# Altium schematic sheet Sheet2.SchDoc(Sheet2)
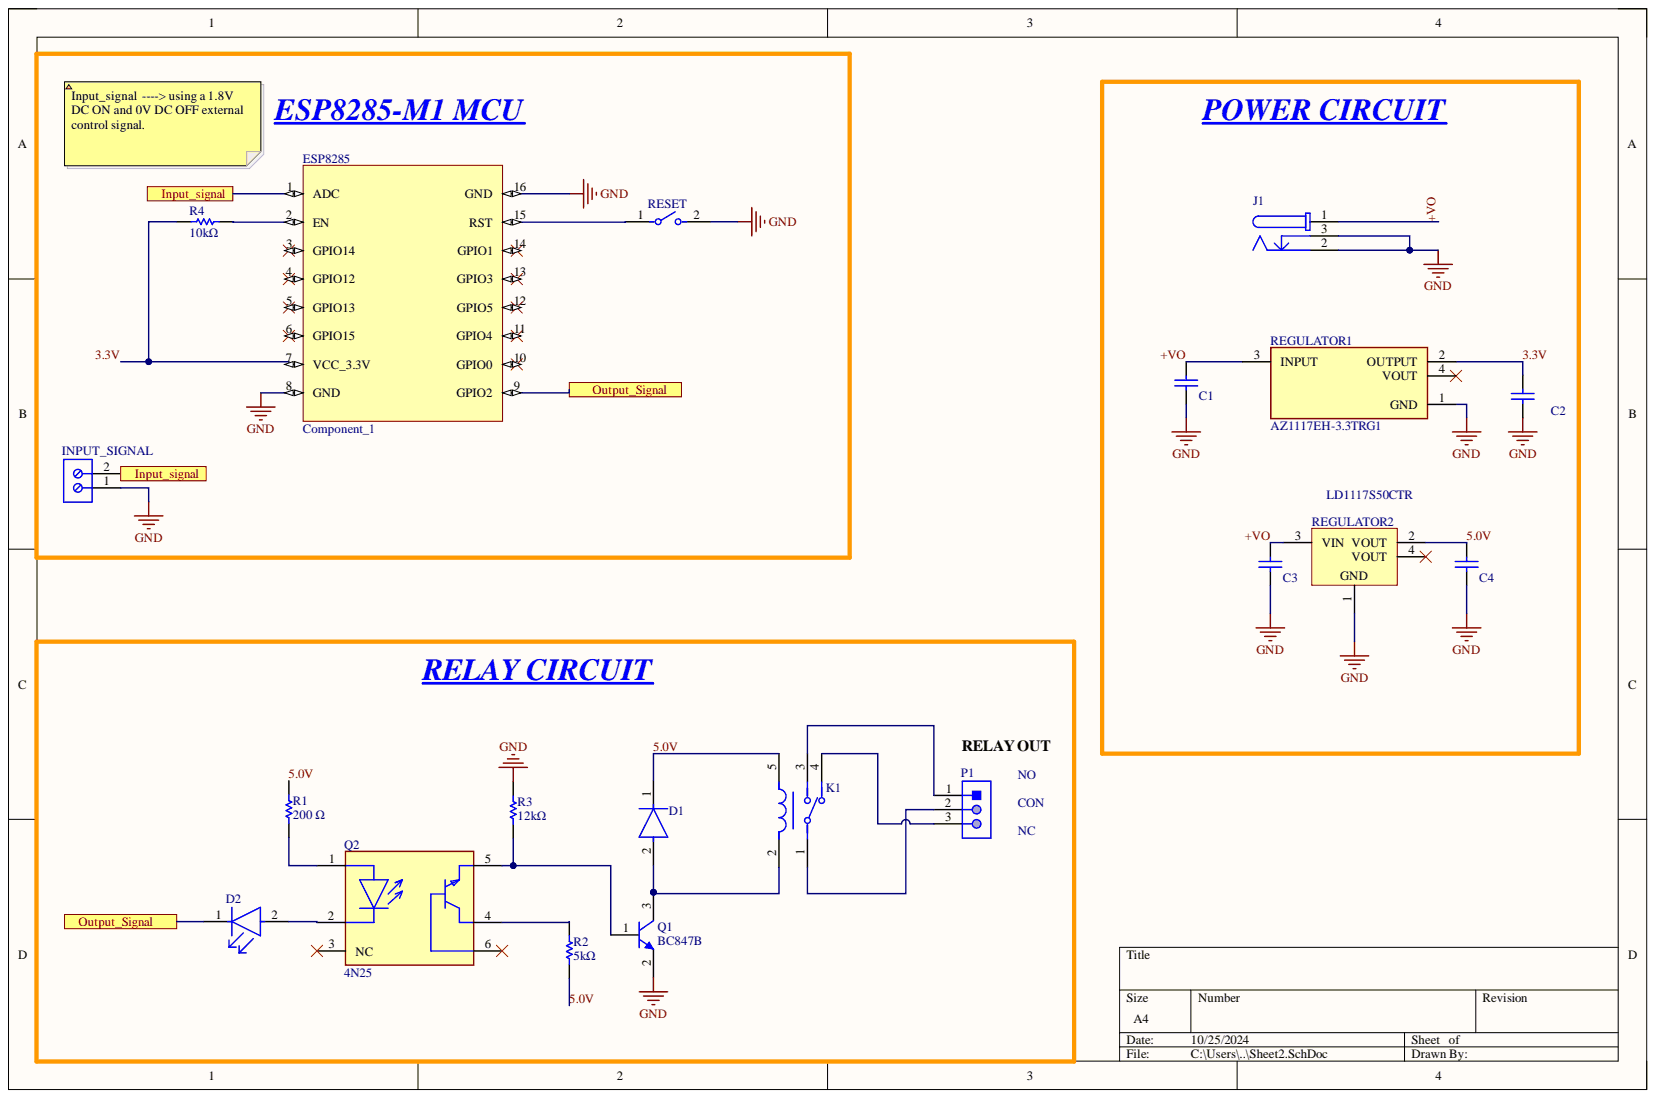
[diagram p0: Sheet2.SchDoc]

## Parts
C1  0603YC104KAT4A  [CAPC1608X90X35LL15T15]  (value not drawn)
C2  0603YC104KAT4A  [CAPC1608X90X35LL15T15]  (value not drawn)
C3  0603YC104KAT4A  [CAPC1608X90X35LL15T15]  (value not drawn)
C4  0603YC104KAT4A  [CAPC1608X90X35LL15T15]  (value not drawn)
D1  PMLL4148L,115  [FP-SOD80C-MFG]  (value not drawn)
D2  LTST-C190GKT  [FP-LTST-C190GKT-MFG]  (value not drawn)
ESP8285  Component_1  [ESP8285-M1]
INPUT_SIGNAL  691101710002  [691101710002]  (value not drawn)
J1  694106301002  [694106301002]  (value not drawn)
K1  G5LE-14-DC5  [FP-G5LE-14-DC5-MFG]  (value not drawn)
P1  691311500103  [691311500103]  (value not drawn)
Q1  BC847B  [INF-SOT23_M]
Q2  4N25  [FP-4N25-MFG]
R1  200 Ω  [RESC3225X70X50ML20T20]
R2  5kΩ  [RESC3225X70X50ML20T20]
R3  12kΩ  [RESC3225X70X50ML20T20]
R4  10kΩ  [RESC3225X70X50ML20T20]
REGULATOR1  AZ1117EH-3.3TRG1  [FP-SOT223-MFG]
REGULATOR2  LD1117S50CTR  [SOT-223_M]
RESET  PTS635SL43SMTR LFS  [FP-PTS635SL43SMTR_LFS-MFG]  (value not drawn)

## Ports
Input_signal, Output_Signal

## Nets
GND: C1.1 C2.1 C3.1 C4.1 ESP8285.8(GND) ESP8285.16(GND) INPUT_SIGNAL.1 J1.2 J1.3 Q1.2 R3.2 REGULATOR1.1(GND) REGULATOR2.1 RESET.2
3.3V: C2.2 ESP8285.7(VCC_3.3V) R4.2 REGULATOR1.2(OUTPUT)
5.0V: C4.2 D1.1 K1.5 R1.1 R2.1 REGULATOR2.2(VOUT)
+VO: C1.2 C3.2 J1.1 REGULATOR1.3(INPUT) REGULATOR2.3(VIN)
Input_signal: ESP8285.1(ADC) INPUT_SIGNAL.2 ; port Input_signal
Output_Signal: D2.1 ESP8285.9(GPIO2) ; port Output_Signal
NetD1_2: D1.2 K1.2 Q1.3
NetD2_2: D2.2 Q2.2
NetESP8285_15: ESP8285.15(RST) RESET.1
NetK1_1: K1.1 P1.2
NetK1_3: K1.3 P1.1
NetK1_4: K1.4 P1.3
NetQ1_1: Q1.1 Q2.5 R3.1
NetQ2_1: Q2.1 R1.2
NetQ2_4: Q2.4 R2.2

Not connected: ESP8285 2(EN) 3(GPIO14) 4(GPIO12) 5(GPIO13) 6(GPIO15) 10(GPIO0) 11(GPIO4) 12(GPIO5) 13(GPIO3) 14(GPIO1); Q2 3(NC) 6; R4 1; REGULATOR1 4(VOUT); REGULATOR2 4(VOUT)

## Library data of the parts
C1-C4 = CMP-2006-04495-1: Manufacturer: AVX Interconnect / Elco; Component Kind: Standard; Library Name: Altium Content Vault; Part Number: 0603YC104KAT4A
D1 = CMP-14399-000003-1: DIODE GEN PURP 75V 200MA LLDS; Manufacturer: Nexperia; Capacitance: 4pF; Max Junction Temp: +200°C; Max Repetitive Reverse Voltage: 100V; Max Surge Forward Current: 0.5A; Peak Reverse Current: 0.025uA; Value: 4pF; Ambient Temperature Range High: +200°C; Ambient Temperature Range Low: -65°C; Automotive: No; Category: Diode; Component Kind: Standard; ComponentLink2Description: Datasheet; ComponentLink2URL: https://assets.nexperia.com/documents/data-sheet/PMLL4148L_PMLL4448.pdf; Device Class L1: Discrete Semiconductors; Device Class L2: Diodes; Device Class L3: Rectifier Diodes; Digikey Description: DIODE GEN PURP 75V 200MA LLDS; Footprint Url: https://assets.nexperia.com/documents/outline-drawing/SOD80C.pdf; Forward Current: 200mA; Height: 1.6mm; Lead Free: Yes; Library Name: Altium Content Vault; Manufacturer Part Number: PMLL4148L,115; Octopart: https://octopart.com/pmll4148l%2C115-nexperia-78741557; Package: SOD80C; Reverse Voltage: 75V; RoHS: TRUE; Temperature: to +200°C; Temperature Range High: +200°C
D2 = CMP-22017-000005-2: LED GREEN CLEAR CHIP SMD; Dominant Wavelength: 569nm; Forward Current: 30mA; Forward Voltage: 2.1V; Lens Color: Colorless; Luminous Intensity: 6mcd; Number of Elements: 1; Power Dissipation: 100mW; Reverse Voltage: 5V; Test Current: 10mA; Viewing Angle: 130°; Voltage: 2V; Wavelength: 565nm; Case Code (Imperial): 0603; Case Code (Metric): 1608; Case/Package: 0603; Color: Green; Component Kind: Standard; Depth: 0.8mm; Height: 0.8mm; Illumination Color: Green; Lead Free: Lead Free; Length: 1.6mm; Lens Style: Clear; Lens Transparency: Clear; Library Name: Altium Content Vault; Max Operating Temperature: 85°C; Min Operating Temperature: -55°C; Mount: Surface Mount; Number of LEDs: 1; Number of Pins: 2; Package Quantity: 1; Packaging: Tape and Reel; Power Rating: 100mW; Radiation Hardening: No; Width: 0.8mm
INPUT_SIGNAL = CMP-1502-03256-1: Series 101 - 5.00 mm Horizontal Entry Modular with Pressure Clamp WR-TBL, 2 pin; Manufacturer: Wurth Elektronik; Pin Number: 2; Pitch: 5.00mm; Component Kind: Standard; Datasheet URL: http://katalog.we-online.de/em/datasheet/6911017100xx.pdf; Library Name: Altium Content Vault; Manufacturer URL: http://www.we-online.de; Mounting Technology: THT; Operating Temperature: -40to105degC; Package Reference: 691101710002; Packaging: Box; Part Number: 691101710002; Publisher: WurthElektronik
J1 = CMP-1502-00188-1: DC Power Jack Connector WR-DC, Male, Right Angled, THT, 5 A, 24 V; Manufacturer: Wurth Elektronik; Opening Diameter: 6.4mm; Plug Inner Diameter: 2.05mm; Plug Outer Diameter: 5.5mm; Rated Current: 5A; Working Voltage: 24V; Component Kind: Standard; Datasheet URL: http://katalog.we-online.de/em/datasheet/6941xx301002.pdf; Gender: Male; Library Name: Altium Content Vault; Manufacturer URL: http://www.we-online.de; Mounting Technology: THT; Operating Temperature: -40to85degC; Orientation: RightAngled; Package Reference: 694106301002; Packaging: Bag; Part Number: 694106301002; Publisher: WurthElektronik
K1 = CMP-08822-000008-1: RELAY GEN PURPOSE SPDT 10A 5V; Manufacturer: Omron; Coil Current: 79.4mA; Coil Power: 400mW; Coil Resistance: 63Ω; Coil Type: Non-Latching; Number Of Contacts: 1; Standoff Height: 0.5mm; Ambient Temperature Range High: +85°C; Ambient Temperature Range Low: -25°C; Automotive: No; Category: Relay; Coil Voltage: 5V; Component Kind: Standard; ComponentLink2Description: Datasheet; ComponentLink2URL: https://omronfs.omron.com/en_US/ecb/products/pdf/en-g5le.pdf; Current Rating: 10A; Device Class L1: Electromechanical; Device Class L2: Relays; Device Class L3: Power Relays; Digikey Description: RELAY GEN PURPOSE SPDT 10A 5V; Height: 19mm; Lead Free: Yes; Library Name: Altium Content Vault; Manufacturer Part Number: G5LE-14-DC5; Mouser Description: General Purpose Relays Power PCB Relay SPDT Sealed 5VDC; Octopart: https://octopart.com/g5le-14-dc5-omron-28829; Package: 0; RoHS: TRUE; Switching Current: 10A; Temperature: -25°C to +85°C; Temperature Range High: +85°C; Temperature Range Low: -25°C; Throw Configuration: SPDT
P1 = CMP-1502-02147-1: Serie 311 - 5.08mm Close Vertical PCB Header WR-TBL, 3 pin; Manufacturer: Wurth Elektronik; Gender: Male; Pin Number: 3; Component Kind: Standard; Datasheet URL: http://katalog.we-online.de/em/datasheet/6913115001xx.pdf; Library Name: Altium Content Vault; Manufacturer URL: http://www.we-online.de; Mounting Technology: THT; Operating Temperature: -40to105degC; Package Reference: 691311500103; Packaging: Box; Part Number: 691311500103; Pitch: 5.08mm; Publisher: WurthElektronik
Q1 = CMP-1177-00042-1: NPN Silicon AF Transistor, 45 V VCEO, 100 mA IC, SOT23, Reel, Green; Manufacturer: Infineon; fT (typ): 250Â MHz; Green: TRUE; IBM (max): 200Â mA; IC (max): 100Â mA; ICBO (max): 15Â nA; Ptot (max): 330Â mW; VCBO (max): 50Â V; VCEO (max): 45Â V; VEBO (max): 6Â V; Component Kind: Standard; ComponentLink1Description: Manufacturer URL; ComponentLink1URL: http://www.infineon.com/; ComponentLink2Description: Datasheet; ComponentLink2URL: http://www.infineon.com/dgdl/bc847series_bc848series_bc849series_bc850series.pdf?folderId=db3a304314dca389011541d30fa21656&fileId=db3a304314dca389011541d4630a1657; ComponentLink3Description: Package Specification; ComponentLink3URL: http://www.infineon.com/dgdl/SOT23-Package_Overview.pdf?folderId=db3a30431936bc4b0119532290053c66&fileId=db3a30431936bc4b0119533468123c85; ICM (max): 200Â mA; Library Name: Altium Content Vault; Mounting Technology: Surface Mount; PackageDescription: 3-Pin Small Outline Transistor Package, 1.3 x 2.9 mm Body, 0.95 mm Pitch; PackageReference: SOT23; PackageVersion: Apr-08; Packing: Reel; PartNumber: BC847B; Polarity: NPN
Q2 = CMP-22018-000009-1: OPTOISO 2.5KV TRANS W/BASE 6DIP; Collector Emitter Breakdown Voltage: 30V; Collector Emitter Saturation Voltage: 500mV; Current Transfer Ratio: 50%; Fall Time: 2us; Forward Current: 60mA; Forward Voltage: 1.5V; Input Current: 50mA; Input Type: DC; Isolation Voltage: 5kV; Max Collector Current: 100mA; Max Input Current: 80mA; Max Power Dissipation: 250mW; Number of Channels: 1; Output Voltage: 70V; Power Dissipation: 150mW; Reverse Breakdown Voltage: 5V; Case/Package: PDIP; Collector Emitter Voltage (VCEO): 30V; Collector-emitter Voltage-Max: 500mV; Component Kind: Standard; Isolation Voltage-Max: 5kV; Lead Free: Lead Free; Library Name: Altium Content Vault; Max Operating Temperature: 100°C; Max Output Voltage: 30V; Min Operating Temperature: -55°C; Mount: Through Hole; Number of Circuits: 1; Number of Elements: 1; Number of Pins: 6; Output Current per Channel: 100mA; Package Quantity: 2000; Packaging: Rail/Tube; Radiation Hardening: No; Rise Time: 2us; Series: -
R1-R4 = CMP-2005-03100-1: Manufacturer: Panasonic; Part Number: ERJ-14NF1180U; Component Kind: Standard; Library Name: Altium Content Vault
REGULATOR1 = CMP-12756-000039-1: IC REG LINEAR 3.3V 1A SOT223; Accuracy: 1%; Dropout Voltage: 1.1V; Max Input Voltage: 13V; Number of Outputs: 1; Operating Supply Current: 3.5mA; Output Type: Fixed; Polarity: Positive; Power Supply Rejection Ratio (PSRR): 70dB; Reference Voltage: 1.262V; Case/Package: TO-261-4; Component Kind: Standard; Contact Plating: Tin; Lead Free: Lead Free; Library Name: Altium Content Vault; Max Operating Temperature: 125°C; Max Output Current: 1A; Min Operating Temperature: -40°C; Mount: Surface Mount; Number of Pins: 3; Number of Regulators: 1; Output Current: 1A; Output Voltage: 3.3V; Package Quantity: 4000; Packaging: Tape and Reel; Quiescent Current: 3.5mA; Weight: 0.000282oz
REGULATOR2 = CMP-0244-00311-1: Fixed Low Drop Positive Voltage Regulator, 5V, 4-Pin SOT-223, Tape and Reel; Component Kind: Standard; ComponentLink1Description: Manufacturer URL; ComponentLink1URL: http://www.st.com; ComponentLink2Description: Datasheet; ComponentLink2URL: http://www.st.com/internet/com/TECHNICAL_RESOURCES/TECHNICAL_LITERATURE/DATASHEET/CD00000544.pdf; DatasheetVersion: 1/11/2011; Library Name: Altium Content Vault; PackageDescription: 4-Pin SOT-223 Package, 6.5 x 3.5 mm Body, 2.3 mm Pitch, 7 mm Lead Span; PackageReference: SOT-223; PackageVersion: 2010
RESET = CMP-10040-000005-1: SWITCH TACTILE SPST-NO 0.05A 12V; Manufacturer: C & K; Contact Current Rating: 50mA; Contact Resistance: 100mΩ; Electromechanical Life: 20000Cycles; Operating Force: 130gf; Throw Configuration: SPST; Automotive: No; Category: Switch; Component Kind: Standard; ComponentLink2Description: Datasheet; ComponentLink2URL: https://www.ckswitches.com/media/1473/pts635.pdf; Device Class L1: Electromechanical; Device Class L2: Switches; Device Class L3: Tactile Switches; Digikey Description: SWITCH TACTILE SPST-NO 0.05A 12V; Height: 4.3mm; Lead Free: Yes; Library Name: Altium Content Vault; Manufacturer Part Number: PTS635SL43SMTR LFS; Mouser Description: Tactile Switches Switch Tact 6X3.5Mm Mom Spst Smd; Octopart: https://octopart.com/pts635sl43smtr+lfs-c%26k+components-12650086; Package: PTS635SL43SMTR LFS; RoHS: TRUE; Temperature: -40°C to +60°C; Temperature Range High: +60°C; Temperature Range Low: -40°C; Voltage Rating Dc: 12V
ESP8285 = Component_1: Component Kind: Standard; Library Name: ESP8285.SchLib
